# Altium schematic sheet ExpBoard.SchDoc
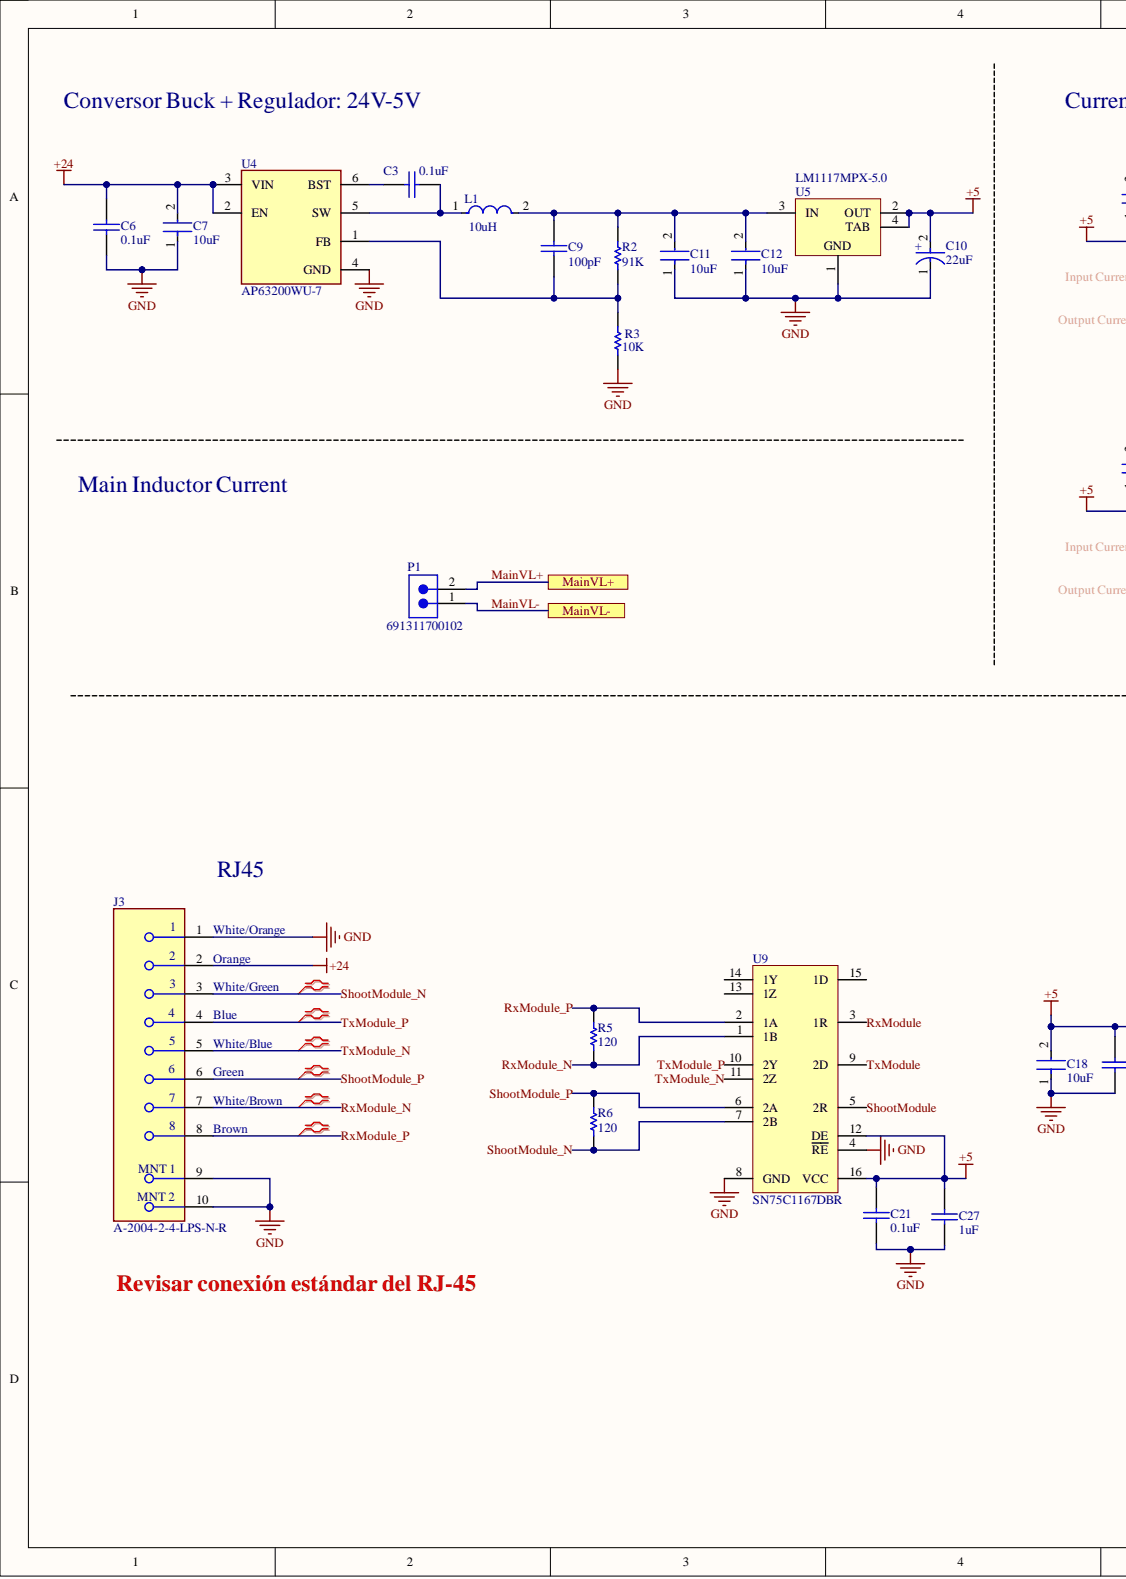
[diagram p0: ExpBoard.SchDoc, left]
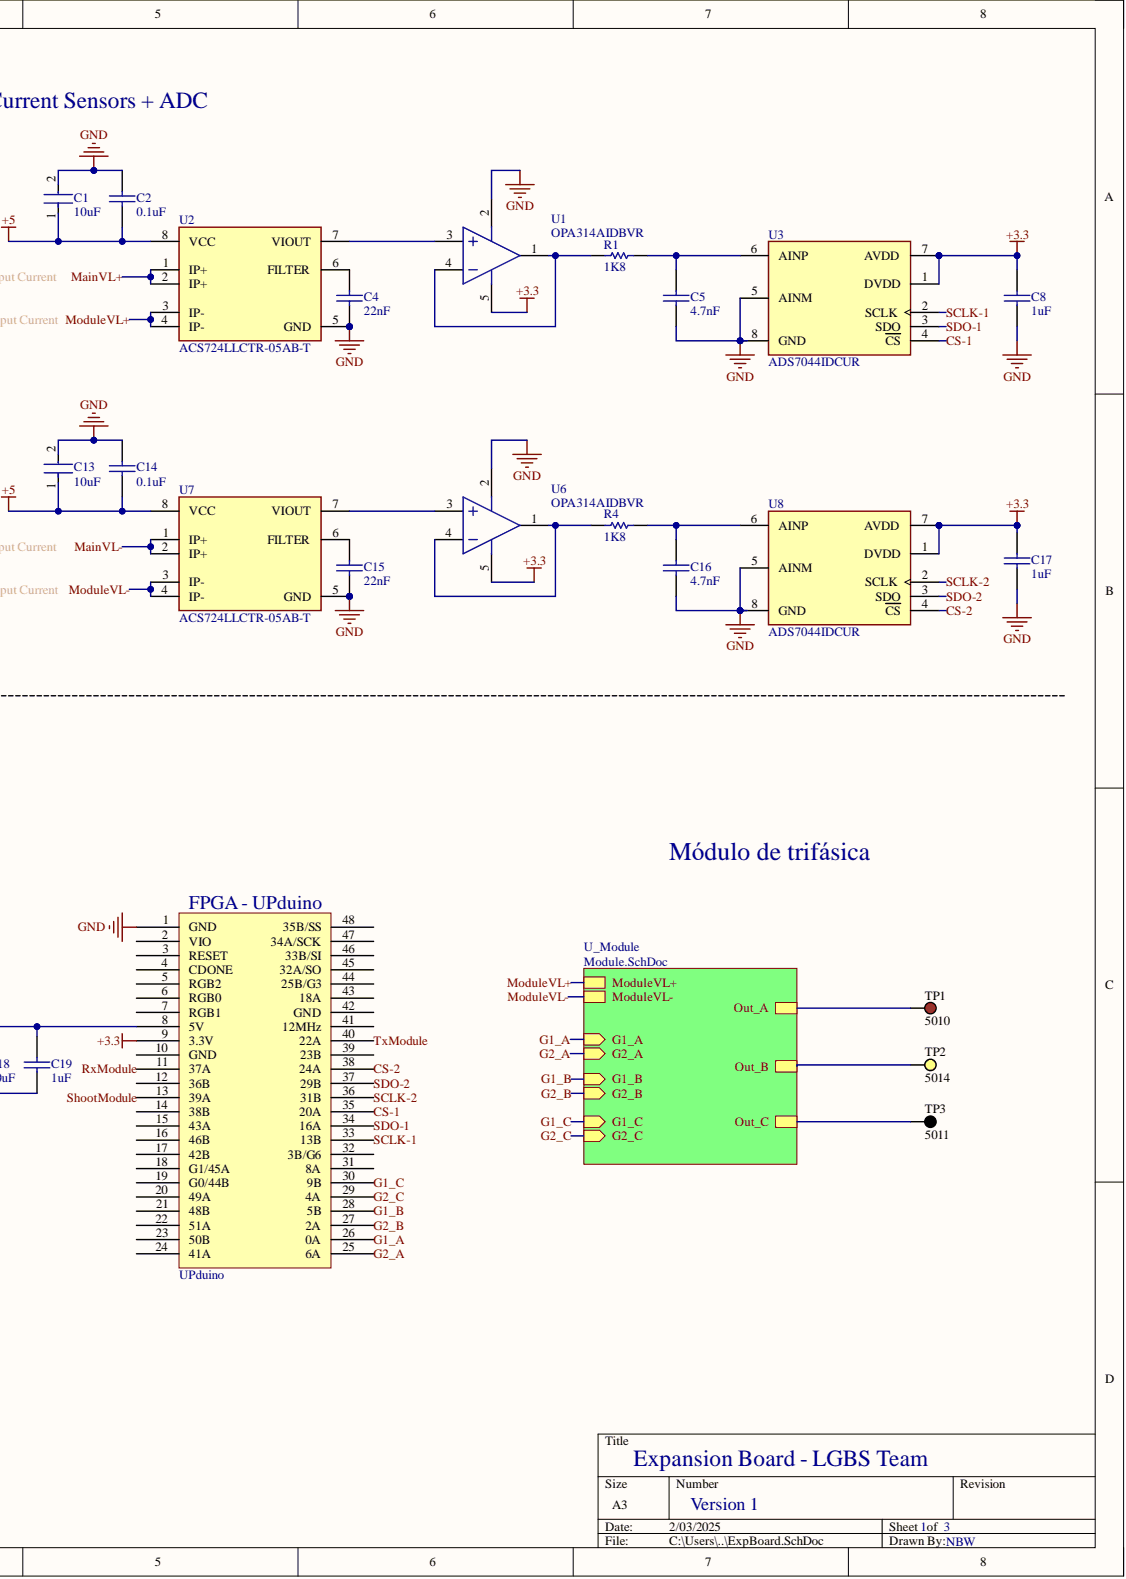
[diagram p1: ExpBoard.SchDoc, right]

## Parts
C1  10uF  [FP-CL31B106KBHNNNE-IPC_A]
C2  0.1uF  [0805]
C3  0.1uF  [0805]
C4  22nF  [0805]
C5  4.7nF  [0805]
C6  0.1uF  [0805]
C7  10uF  [FP-CL31B106KBHNNNE-IPC_A]
C8  1uF  [0805]
C9  100pF  [0805]
C10  22uF  [FP-293DC-MFG]
C11  10uF  [FP-CL31B106KBHNNNE-IPC_A]
C12  10uF  [FP-CL31B106KBHNNNE-IPC_A]
C13  10uF  [FP-CL31B106KBHNNNE-IPC_A]
C14  0.1uF  [0805]
C15  22nF  [0805]
C16  4.7nF  [0805]
C17  1uF  [0805]
C18  10uF  [FP-CL31B106KBHNNNE-IPC_A]
C19  1uF  [0805]
C21  0.1uF  [0805]
C27  1uF  [0805]
FPGA_-_UPduino  UPduino  [FPGA]
J3  A-2004-2-4-LPS-N-R  [FP-A-2004-2-4-LPS-N-R-MFG]
L1  10uH  [FP-NR6045-MFG]
P1  691311700102  [691311700102]
R1  1K8  [0805]
R2  91K  [0805]
R3  10K  [0805]
R4  1K8  [0805]
R5  120  [0805]
R6  120  [0805]
TP1  5010  [KSTN-5010_V]
TP2  5014  [KSTN-5014_V]
TP3  5011  [KSTN-5011_V]
U1  OPA314AIDBVR  [DBV0005A_M]
U2  ACS724LLCTR-05AB-T  [FP-LCN-8-IPC_B]
U3  ADS7044IDCUR  [FP-DCU0008A-MFG]
U4  AP63200WU-7  [FP-TSOT26-MFG]
U5  LM1117MPX-5.0  [DCY0004A_L]
U6  OPA314AIDBVR  [DBV0005A_M]
U7  ACS724LLCTR-05AB-T  [FP-LCN-8-IPC_B]
U8  ADS7044IDCUR  [FP-DCU0008A-MFG]
U9  SN75C1167DBR  [MC34050]

## Ports
MainVL+, MainVL-

## Nets
+3.3: C8.1 C17.1 FPGA_-_UPduino.9(3.3V) U1.5 U3.1(DVDD) U3.7(AVDD) U6.5 U8.1(DVDD) U8.7(AVDD)
+5: C1.1 C2.2 C10.2 C13.1 C14.2 C18.2 C19.1 C21.1 C27.1 FPGA_-_UPduino.8(5V) U2.8(VCC) U5.2(OUT) U5.4(TAB) U7.8(VCC) U9.12(DE) U9.16(VCC)
+24: C6.1 C7.2 J3.2 U4.2(EN) U4.3(VIN)
GND: C1.2 C2.1 C4.2 C5.2 C6.2 C7.1 C8.2 C10.1 C11.1 C12.1 C13.2 C14.1 C15.2 C16.2 C17.2 C18.1 C19.2 C21.2 C27.2 FPGA_-_UPduino.1(GND) J3.1 J3.9 J3.10 R3.2 U1.2 U2.5(GND) U3.5(AINM) U3.8(GND) U4.4(GND) U5.1 U6.2 U7.5(GND) U8.5(AINM) U8.8(GND) U9.4(RE) U9.8(GND)
CS-1: FPGA_-_UPduino.35(20A) U3.4(CS)
CS-2: FPGA_-_UPduino.38(24A) U8.4(CS)
G1_A: FPGA_-_UPduino.26(0A)
G1_B: FPGA_-_UPduino.28(5B)
G1_C: FPGA_-_UPduino.30(9B)
G2_A: FPGA_-_UPduino.25(6A)
G2_B: FPGA_-_UPduino.27(2A)
G2_C: FPGA_-_UPduino.29(4A)
MainVL+: P1.2 U2.1(IP+) U2.2(IP+) ; port MainVL+
MainVL-: P1.1 U7.1(IP+) U7.2(IP+) ; port MainVL-
ModuleVL+: U2.3(IP-) U2.4(IP-)
ModuleVL-: U7.3(IP-) U7.4(IP-)
RxModule: FPGA_-_UPduino.11(37A) U9.3(1R)
RxModule_N: J3.7 R5.1 U9.1(1B)
RxModule_P: J3.8 R5.2 U9.2(1A)
SCLK-1: FPGA_-_UPduino.33(13B) U3.2(SCLK)
SCLK-2: FPGA_-_UPduino.36(31B) U8.2(SCLK)
SDO-1: FPGA_-_UPduino.34(16A) U3.3(SDO)
SDO-2: FPGA_-_UPduino.37(29B) U8.3(SDO)
ShootModule: FPGA_-_UPduino.13(39A) U9.5(2R)
ShootModule_N: J3.3 R6.1 U9.7(2B)
ShootModule_P: J3.6 R6.2 U9.6(2A)
TxModule: FPGA_-_UPduino.40(22A) U9.9(2D)
TxModule_N: J3.5 U9.11(2Z)
TxModule_P: J3.4 U9.10(2Y)
NetC3_1: C3.1 U4.6(BST)
NetC3_2: C3.2 L1.1 U4.5(SW)
NetC4_1: C4.1 U2.6(FILTER)
NetC5_1: C5.1 R1.2 U3.6(AINP)
NetC9_1: C9.1 C11.2 C12.2 L1.2 R2.1 U5.3(IN)
NetC9_2: C9.2 R2.2 R3.1 U4.1(FB)
NetC15_1: C15.1 U7.6(FILTER)
NetC16_1: C16.1 R4.2 U8.6(AINP)
NetFPGA - UPduino_2: FPGA_-_UPduino.2(VIO)
NetFPGA - UPduino_3: FPGA_-_UPduino.3(RESET)
NetFPGA - UPduino_4: FPGA_-_UPduino.4(CDONE)
NetFPGA - UPduino_5: FPGA_-_UPduino.5(RGB2)
NetFPGA - UPduino_6: FPGA_-_UPduino.6(RGB0)
NetFPGA - UPduino_7: FPGA_-_UPduino.7(RGB1)
NetFPGA - UPduino_10: FPGA_-_UPduino.10(GND)
NetFPGA - UPduino_12: FPGA_-_UPduino.12(36B)
NetFPGA - UPduino_14: FPGA_-_UPduino.14(38B)
NetFPGA - UPduino_15: FPGA_-_UPduino.15(43A)
NetFPGA - UPduino_16: FPGA_-_UPduino.16(46B)
NetFPGA - UPduino_17: FPGA_-_UPduino.17(42B)
NetFPGA - UPduino_18: FPGA_-_UPduino.18(G1/45A)
NetFPGA - UPduino_19: FPGA_-_UPduino.19(G0/44B)
NetFPGA - UPduino_20: FPGA_-_UPduino.20(49A)
NetFPGA - UPduino_21: FPGA_-_UPduino.21(48B)
NetFPGA - UPduino_22: FPGA_-_UPduino.22(51A)
NetFPGA - UPduino_23: FPGA_-_UPduino.23(50B)
NetFPGA - UPduino_24: FPGA_-_UPduino.24(41A)
NetFPGA - UPduino_31: FPGA_-_UPduino.31(8A)
NetFPGA - UPduino_32: FPGA_-_UPduino.32(3B/G6)
NetFPGA - UPduino_39: FPGA_-_UPduino.39(23B)
NetFPGA - UPduino_41: FPGA_-_UPduino.41(12MHz)
NetFPGA - UPduino_42: FPGA_-_UPduino.42(GND)
NetFPGA - UPduino_43: FPGA_-_UPduino.43(18A)
NetFPGA - UPduino_44: FPGA_-_UPduino.44(25B/G3)
NetFPGA - UPduino_45: FPGA_-_UPduino.45(32A/SO)
NetFPGA - UPduino_46: FPGA_-_UPduino.46(33B/SI)
NetFPGA - UPduino_47: FPGA_-_UPduino.47(34A/SCK)
NetFPGA - UPduino_48: FPGA_-_UPduino.48(35B/SS)
NetR1_1: R1.1 U1.1 U1.4
NetR4_1: R4.1 U6.1 U6.4
NetTP1_1: TP1.1
NetTP2_1: TP2.1
NetTP3_1: TP3.1
NetU1_3: U1.3 U2.7(VIOUT)
NetU6_3: U6.3 U7.7(VIOUT)
NetU9_13: U9.13(1Z)
NetU9_14: U9.14(1Y)
NetU9_15: U9.15(1D)

## Library data of the parts
C1 C7 C11-C13 C18 = CMP-2000-07530-2: CAP CER 10UF 50V X7R 1206 -CL31B106KBHNNNE; Manufacturer: Samsung; Material: Ceramic; Temperature Coefficient: 15%; Tolerance: 10%; Automotive: No; Capacitance: 10uF; Category: Cap; Component Kind: Standard; ComponentLink2Description: Datasheet; ComponentLink2URL: http://www.samsungsem.com/global/front/downloadcms.do?path=%2F%2Fglobal%2F%2Fsupport%2F%2Fproduct-search%2F%2Fmlcc%2F%2F__icsFiles%2F%2Fafieldfile%2F%2F2019%2F%2F06%2F%2F18&fileName=CL31B106KBHNNNE-19.pdf; Device Class L1: Passive Components; Device Class L2: Capacitors; Device Class L3: Ceramic Capacitors; Digikey Description: CAP CER 10UF 50V X7R 1206; Height: 1.8mm; Lead Free: Yes; Library Name: Altium Content Vault; Manufacturer Part Number: CL31B106KBHNNNE; Octopart: https://octopart.com/cl31b106kbhnnne-samsung-30507377; Package: CL31B106KBHNNNE; RoHS: TRUE; Temperature: -55°C to +125°C; Temperature Characteristic: X7R; Temperature Range High: +125°C; Temperature Range Low: -55°C; Value: 10uF; Voltage Rating: 50V
C2-C6 C8 C9 C14-C17 C19 C21 C27 = CAP: Component Kind: Standard; Library Name: LIBEBAL.IntLib
C10 = CMP-02414-000014-1: CAP TANT 22UF 10% 16V 2312 - 293D226X9016C2TE3; Dielectric Material: Tantalum; Dissipation Factor: 6%; ESR (Equivalent Series Resistance): 1.4R; Leakage Current: 3.5uA; Polarity: Polar; Q Factor: 6; Ripple Current: 210mA; Capacitance: 22uF; Case Code (Imperial): 2312; Case Code (Metric): 6032; Case/Package: Molded; Component Kind: Standard; Depth: 3.2mm; Features: General Purpose; Height: 2.5mm; Height - Seated (Max): 0.11inch; Lead Free: Lead Free; Length: 6mm; Library Name: Altium Content Vault; Max Operating Temperature: 125°C; Min Operating Temperature: -55°C; Mount: Surface Mount; Package Quantity: 1; Packaging: Tape and Reel; Radiation Hardening: No; RoHS Compliant: Yes; Series: TANTAMOUNT® 293D; Termination: SMD/SMT; Tolerance: 10%; Voltage: 16V; Voltage Rating: 16V; Voltage Rating (DC): 16V; Width: 0.126inch
FPGA_-_UPduino = FPGA: upduino v3.0; Component Kind: Standard; Library Name: MCSI.SchLib
J3 = CMP-39752-000001-1: CONN MOD JACK 8P8C R/A SHIELDED; Direction: Down; Gender: Female; Max Current Rating: 1.5A; Max Voltage Rating (AC): 120V; Number of Contacts: 8; Number of Ports: 1; Pitch: 2.54mm; Component Kind: Standard; Connector Type: Jack; Contact Material: Bronze; Contact Plating: Gold; Housing Material: PBT; Lead Free: Lead Free; Library Name: Altium Content Vault; Max Operating Temperature: 85°C; Min Operating Temperature: -40°C; Mount: Through Hole; Number of Rows: 1; Orientation: Right Angle; Package Quantity: 100; Packaging: Tray; RoHS Compliant: Yes; Series: -; Shielding: Shielded; Termination: Solder
L1 = CMP-14484-001321-1: Shielded Wirewound Inductor 10uH 20% 2.8A 59.8mΩ SMD - NRS6045T100MMGK; Core Material: Ferrite; Current Rating: 2.4A; DC Resistance (DCR): 59.8mR; Max DC Current: 3.1A; Self Resonant Frequency: 12MHz; Test Frequency: 100kHz; Case/Package: Nonstandard; Component Kind: Standard; Depth: 6.2mm; Halogen Free: Halogen Free; Height: 4.5mm; Height - Seated (Max): 0.177inch; Inductance: 10uH; Inductance Tolerance: 20%; Lead Free: Lead Free; Lead Pitch: 4.7mm; Length: 6mm; Library Name: Altium Content Vault; Max Operating Temperature: 125°C; Min Operating Temperature: -25°C; Mount: Surface Mount; Number of Pins: 2; Package Quantity: 1500; Packaging: Tape and Reel; Radiation Hardening: No; Resistor Type: Wirewound; RMS Current (Irms): 2.4A; RoHS Compliant: Yes; Saturation Current: 3.1A; Series: NRS; Shielding: Shielded; Termination: SMD/SMT; Tolerance: 20%; Width: 0.236inch
P1 = CMP-1502-02033-2: NexarPartId: 18818310; Current: 20A; Gender: Male; Number of Contacts: 2; Number of Levels: 1; Pitch: 5.0038mm; Voltage: 300V; Color: Green; Component Kind: Standard; Contact Material: Brass; Height: 12mm; Lead Pitch: 5mm; Length: 8.5mm; Library Name: Altium Content Vault; Mount: Through Hole; Number of Pins: 2; Number of Positions Per Level: 2; Number of Rows: 1; Orientation: Vertical; Packaging: Box; REACH SVHC: Unknown; RoHS: Compliant; Termination: Solder; Width: 12mm
R1-R6 = RES: Component Kind: Standard; Library Name: LIBEBAL.IntLib
TP1 = CMP-1672-00002-4: Test Point, Red, Through Hole, RoHS, Bulk; Manufacturer: Keystone; Colour: Red; Component Kind: Standard; ComponentLink1Description: Manufacturer URL; ComponentLink1URL: http://www.keyelco.com/; ComponentLink2Description: Datasheet; ComponentLink2URL: http://www.keyelco.com/product-pdf.cfm?p=1319; Contact Material: Bronze; Contact Plating: Silver; DatasheetVersion: Rev. F, 12/2013; Height: 8.12 mm; Library Name: Altium Content Vault; Mount: Through Hole; Mounting Technology: Through Hole; Package Quantity: 100; PackageDescription: 1-Pin Through Hole Device, Dia 3.17 mm; PackageReference: 5010; Packaging: Bulk; Pins: 1; REACH SVHC: No SVHC; RoHSCompliant: true
TP2 = CMP-1672-00006-4: Test Point, Yellow, Through Hole, RoHS, Bulk; Manufacturer: Keystone; Colour: Yellow; Component Kind: Standard; ComponentLink1Description: Manufacturer URL; ComponentLink1URL: http://www.keyelco.com/; ComponentLink2Description: Datasheet; ComponentLink2URL: http://www.keyelco.com/product-pdf.cfm?p=1323; Contact Material: Bronze; Contact Plating: Silver; DatasheetVersion: Rev. F, 12/2013; Height: 8.12 mm; Library Name: Altium Content Vault; Mount: Through Hole; Mounting Technology: Through Hole; Package Quantity: 100; PackageDescription: 1-Pin Through Hole Device, Dia 3.17 mm; PackageReference: 5014; Packaging: Bulk; REACH SVHC: No SVHC; RoHSCompliant: true
TP3 = CMP-1672-00003-4: Test Point, Black, Through Hole, RoHS, Bulk; Manufacturer: Keystone; Colour: Black; Component Kind: Standard; ComponentLink1Description: Manufacturer URL; ComponentLink1URL: http://www.keyelco.com/; ComponentLink2Description: Datasheet; ComponentLink2URL: http://www.keyelco.com/product-pdf.cfm?p=1320; Contact Material: Bronze; Contact Plating: Silver; DatasheetVersion: Rev. F, 12/2013; Height: 8.12 mm; Library Name: Altium Content Vault; Mount: Through Hole; Mounting Technology: Through Hole; Package Quantity: 100; PackageDescription: 1-Pin Through Hole Device, Dia 3.17 mm; PackageReference: 5011; Packaging: Bulk; Pins: 1; REACH SVHC: No SVHC; RoHSCompliant: true
U1 U6 = CMP-0272-00944-3: 3 MHz, Low-Power, Low-Noise, RR I/O, CMOS Operational Amplifier, 1.8 to 5.5 V, -40 to 125 degC, 5-pin SOT23 (DBV5), Green (RoHS & no Sb/Br); Manufacturer: Texas Instruments; CMRR(Min)(dB): 75; GBW(Typ)(MHz): 3; IIB(Max)(pA): 10; Iq per channel(Max)(mA): 0.21; Number of Channels: 1; Packing: LARGE T&R; Rating: Catalog; Slew Rate(Typ)(V/us): 1.5; Sub Family: Low Input Bias Current Amp (<=10pA), Low Power Amp (<=500uA), Low Supply Voltage Amplifier (<=2.7V), Precision Amplifier; Total Supply Voltage(Max)(+5V is 5, +/-5V is 10): 5.5; Total Supply Voltage(Min)(+5V is 5, +/-5V is 10): 1.8; Vio (25C)(Max)(mV): 2.5; Code_JEDEC: MO-178; Component Kind: Standard; ComponentLink1Description: Manufacturer URL; ComponentLink1URL: http://www.ti.com/; ComponentLink2Description: Datasheet; ComponentLink2URL: http://www.ti.com/lit/ds/symlink/opa314.pdf; ComponentLink3Description: Package Specification; ComponentLink3URL: http://www.ti.com/litv/pdf/mpds018i; DatasheetVersion: SBOS563E; Eco-Plan: Green (RoHS & no Sb/Br); Library Name: Altium Content Vault; Mounting Technology: Surface Mount; Offset Drift(Typ)(uV/C): 1; PackageDescription: 5-Pin Plastic Small Outline, Body 1.6 x 2.9 mm, Pitch 0.95 mm; PackageReference: DBV0005A; PackageVersion: 4073253-4/K, 03/2006; PartNumber: OPA314AIDBVR
U2 U7 = CMP-82923-000070-2: SENSOR CURRENT HALL; Accuracy: 0.6%; Current Sensing: 5A; Frequency: 120kHz; Linearity: 1.5%; Max Supply Current: 14mA; Number of Channels: 1; Polarity: Bidirectional; Response Time: 4us; Sensitivity: 400mV/A; Case/Package: SOIC; Component Kind: Standard; Library Name: Altium Content Vault; Max Operating Temperature: 150°C; Min Operating Temperature: -40°C; Mount: Surface Mount; Packaging: Tape and Reel; RoHS Compliant: Yes
U3 U8 = CMP-04860-000057-1: IC ADC 12BIT SAR 8VSSOP; Integral Nonlinearity (INL): 2LSB; Interface: SPI; Max Dual Supply Voltage: 3.6V; Max Power Dissipation: 900uW; Min Dual Supply Voltage: 1.8V; Number of A/D Converters: 1; Number of Bits: 12; Operating Supply Voltage: 3V; Polarity: Unipolar; Resolution: 12b; Sampling Rate: 1000000S/s; Signal to Noise Ratio (SNR): 71dB; Supply Type: Analog; Component Kind: Standard; Contact Plating: Gold; Lead Free: Lead Free; Library Name: Altium Content Vault; Max Operating Temperature: 125°C; Max Supply Voltage: 3.6V; Min Operating Temperature: -40°C; Mount: Surface Mount; Number of Analog Inputs: 1; Number of Inputs: 1; Number of Pins: 8; Packaging: Tape and Reel; RoHS Compliant: Yes
U4 = CMP-12757-000010-1: IC REG BUCK ADJUSTABLE 2A TSOT26; Manufacturer: Diodes Inc.; Max Junction Temp: +160°C; Max Supply Voltage: 32V; Min Supply Voltage: 3.8V; Nominal Supply Current: 22uA; Number Of Outputs: 1; Output Type: AdjustableProgrammable; Standoff Height: 0.01mm; Switching Frequency: 500kHz; Ambient Temperature Range High: +85°C; Ambient Temperature Range Low: -40°C; Automotive: No; Category: IC; Component Kind: Standard; ComponentLink2Description: Datasheet; ComponentLink2URL: https://www.diodes.com/assets/Datasheets/AP63200-AP63201-AP63203-AP63205.pdf; Device Class L1: Integrated Circuits (ICs); Device Class L2: Power Management ICs; Device Class L3: Voltage Regulators - Switching; Digikey Description: IC REG BUCK ADJUSTABLE 2A TSOT26; Footprint Url: https://www.diodes.com/assets/Package-Files/TSOT26.pdf; Height: 1mm; Ipc Land Pattern Name: SOT95P280X100-6; Lead Free: Yes; Library Name: Altium Content Vault; Manufacturer Part Number: AP63200WU-7; Mouser Description: AP63200 Series 1.2 V 2 A Adjustable Synchronous Buck Converter - TSOT-26; Octopart: https://octopart.com/ap63200wu-7-diodes+inc.-98099548; Output Current: 2A; Package: TSOT26; RoHS: TRUE; Switching Topology: Buck; Temperature: -40°C to +85°C; Temperature Range High: +85°C; Temperature Range Low: -40°C
U5 = CMP-0062-01637-4: 800mA Low-Dropout Linear Regulator, 4-pin SOT-223; Manufacturer: Texas Instruments; CompType: LDO IC; High-Reliability: Y; PackageTopMarking: XYTT*N06A; PowerWise: N; Code_IPC: SOT223-4; Code_JEDEC: TO-261-AA; Component Kind: Standard; ComponentLink1Description: Datasheet URL; ComponentLink1URL: http://www.ti.com/general/docs/lit/getliterature.tsp?genericPartNumber=LM1117-N&fileType=pdf; Library Name: Altium Content Vault; PackageDescription: Molded SOT-223, 6.50 x 3.56 x 1.6mm Body, 4 Lead, 2.3mm Pitch; PackageReference: DCY0004A; PartNumber: LM1117MPX-5.0; PinCount: 4; RoHS: N
U9 = CMP-0310-00497-2: Dual Differential Driver and Receiver, 2 TX / 2 RX, 5V, 0 to 70 degC, 16-Pin SSOP (DB), Green (RoHS & no Sb/Br); Manufacturer: Texas Instruments; Drivers Per Package: 2; ICC(Max)(mA): 9; Rating: Catalog; SubFamily: RS-422; Supply Voltage(s)(V): 5; # of TX/RX: 2 TX / 2 RX; Code_JEDEC: MO-150; Component Kind: Standard; ComponentLink1Description: Datasheet; ComponentLink1URL: http://www.ti.com/general/docs/lit/getliterature.tsp?genericPartNumber=SN75C1167&fileType=pdf; ComponentLink2Description: Package Specification; ComponentLink2URL: http://www.ti.com/lit/ml/msso002e/msso002e.pdf; DatasheetVersion: SLLS159F; Eco-Plan: Green (RoHS & no Sb/Br); ESD(kV): 2; Library Name: Altium Content Vault; Mounting Technology: Surface Mount; PackageDescription: 16-Pin Plastic Small Outline Package, 6.2 x 5.3 mm Body, 0.65 mm Pitch; PackageReference: DB0016A; PackageVersion: revE, Dec-2001; PartNumber: SN75C1167DBR; Receivers Per Package: 2
